# Altium schematic sheet [03] - ARDUINO SCHEMATIC.SchDoc("[03] - ARDUINO SCHEMATIC") (continued)

## Library data of the parts
C1 C12 C16 C18 C19 C21 C33 = C2012X5R1C106M125AC: CAP CER 10UF 16V X5R 0805; PCN Part Number: MLCC Part Number Change 30/Nov/2012; Manufacturer: TDK; Tolerance: ±20%; Product Training Modules: C Series General Applications SEAT, CCV, and TVCL Design Tools Guide to Replacing an Electrolytic Capacitor with an MLCC; Other Names: 445-4115-2 C2012X5R1C106M C2012X5R1C106M/1.25 C2012X5R1C106MT C2012X5R1C106MTJ00E; Online Catalog: C Series, General Purpose; Catalog Drawings: C SERIES_2012-0805_1.45; Capacitance: 10µF; Thickness (Max): 0.057" (1.45mm); Temperature Coefficient: X5R; Supplier Part Number 1: 445-4115-1-ND; Supplier 1: Digi-Key; Stock 1: 0; Standard Package: 2,000; Size / Dimension: 0.079" L x 0.049" W (2.00mm x 1.25mm); Series: C; RoHS Information: RoHS Certificate-MLCC; Ratings: -; Packaging: Tape & Reel (TR); Package / Case: 0805 (2012 Metric); Operating Temperature: -55°C ~ 85°C; Mounting Type: Surface Mount, MLCC; Manufacturer Part Number: C2012X5R1C106M125AC; Library Name: Arduino_Project_V1I1.SchLib; Lead Style: -; Lead Spacing: -; Height - Seated (Max): -; Features: -; Family: Ceramic Capacitors; ComponentLink3URL: https://product.tdk.com/info/en/documents/chara_sheet/C2012X5R1C106M125AC.pdf?src-supplier=Newark; ComponentLink3Description: https://product.tdk.com/info/en/documents/chara_sheet/C2012X5R1C106M125AC.pdf?src-supplier=Newark; ComponentLink2URL: http://www.farnell.com/datasheets/1913358.pdf?src-supplier=Element14; ComponentLink2Description: http://www.farnell.com/datasheets/1913358.pdf?src-supplier=Element14; ComponentLink1URL: https://media.digikey.com/pdf/Data%20Sheets/TDK%20PDFs/Multilayer%20Ceramic%20Chip%20Cap(up%20to%2050V).pdf?src-supplier=Digi-Key; ComponentLink1Description: https://media.digikey.com/pdf/Data%20Sheets/TDK%20PDFs/Multilayer%20Ceramic%20Chip%20Cap(up%20to%2050V).pdf?src-supplier=Digi-Key; Component Kind: Standard; Category: Capacitors; Applications: General Purpose
  C1: Voltage - Rated: 16V
C2-C4 C8 C11 C13-C15 C17 C20 C22 C23 C26-C32 = C2012X7R1H104K085AA: CAP CER 0.1UF 50V X7R 0805; PCN Part Number: MLCC Part Number Change 30/Nov/2012; Manufacturer: TDK; Tolerance: ±10%; Product Training Modules: C Series General Applications SEAT, CCV, and TVCL Design Tools Guide to Replacing an Electrolytic Capacitor with an MLCC; Other Names: 445-7534-1; Online Catalog: C Series, General Purpose; Kits: 445-9015-KIT-ND  - KIT MLCC 1000PF-47UF 0805 10-50V; Capacitance: 0.1µF; Voltage - Rated: 50V; Thickness (Max): 0.037" (0.95mm); Temperature Coefficient: X7R; Supplier Part Number 1: 445-7534-1-ND; Supplier 1: Digi-Key; Stock 1: 240552; Standard Package: 1; Size / Dimension: 0.079" L x 0.049" W (2.00mm x 1.25mm); Series: C; RoHS Information: RoHS Certificate-MLCC; Ratings: -; Pricing 1: 1=0.17, 10=0.119, 100=0.0561, 500=0.0394, 1000=0.0326 (USD); Packaging: Cut Tape (CT); Package / Case: 0805 (2012 Metric); Operating Temperature: -55°C ~ 125°C; Mounting Type: Surface Mount, MLCC; Manufacturer Part Number: C2012X7R1H104K085AA; Library Name: Arduino_Project_V1I1.SchLib; Lead Style: -; Lead Spacing: -; Height - Seated (Max): -; Features: -; Family: Ceramic Capacitors; ComponentLink5URL: http://www.farnell.com/datasheets/1571323.pdf?src-supplier=Element14; ComponentLink5Description: http://www.farnell.com/datasheets/1571323.pdf?src-supplier=Element14; ComponentLink4URL: http://www.farnell.com/datasheets/1796654.pdf?src-supplier=Newark; ComponentLink4Description: http://www.farnell.com/datasheets/1796654.pdf?src-supplier=Newark; ComponentLink3URL: http://www.tdk.co.jp/tefe02/e412_c.pdf?src-supplier=Future+Electronics; ComponentLink3Description: http://www.tdk.co.jp/tefe02/e412_c.pdf?src-supplier=Future+Electronics; ComponentLink2URL: https://product.tdk.com/info/en/catalog/datasheets/mlcc_commercial_general_en.pdf?src-supplier=Digi-Key; ComponentLink2Description: https://product.tdk.com/info/en/catalog/datasheets/mlcc_commercial_general_en.pdf?src-supplier=Digi-Key; ComponentLink1URL: http://uk.rs-online.com/webdocs/1532/0900766b81532cd0.pdf?src-supplier=RSComponents; ComponentLink1Description: http://uk.rs-online.com/webdocs/1532/0900766b81532cd0.pdf?src-supplier=RSComponents; Component Kind: Standard; Category: Capacitors; Applications: General Purpose
C5 C6 = EEE-1AA101WR: Cap Aluminum 100uF 10V 20% (5 X 5.4mm) SMD 50mA 1000 hr 85°C T/R; Manufacturer: Panasonic; Type: Can; Lifetime@Temperature: 2000 Hrs @ 85°C; Capacitance: 100 µF; Supplier Part Number 1: PCE3867CT-ND; Supplier 1: Digi-Key; Stock 1: 175082; Series: S; Ripple Current: 50 mA; Rated Voltage: 10 V; Pricing 1: 1=0.32, 10=0.221, 100=0.1328, 500=0.0996 (USD); Operating Temp Range: -40 to +85 °C; Operating Temp-Max: 85 °C; Manufacturer Part Number: EEE-1AA101WR; Library Name: Arduino_Project_V1I1.SchLib; ComponentLink5URL: https://industrial.panasonic.com/cdbs/www-data/pdf/RDE0000/DME0000COL90.pdf?src-supplier=Verical; ComponentLink5Description: https://industrial.panasonic.com/cdbs/www-data/pdf/RDE0000/DME0000COL90.pdf?src-supplier=Verical; ComponentLink4URL: https://industrial.panasonic.com/cdbs/www-data/pdf/RDE0000/DME0000COL90.pdf?src-supplier=Allied+Electronics; ComponentLink4Description: https://industrial.panasonic.com/cdbs/www-data/pdf/RDE0000/DME0000COL90.pdf?src-supplier=Allied+Electronics; ComponentLink3URL: http://industrial.panasonic.com/www-data/pdf/ABA0000/ABA0000CE2.pdf?src-supplier=Future+Electronics; ComponentLink3Description: http://industrial.panasonic.com/www-data/pdf/ABA0000/ABA0000CE2.pdf?src-supplier=Future+Electronics; ComponentLink2URL: https://industrial.panasonic.com/cdbs/www-data/pdf/RDE0000/DME0000COL90.pdf?src-supplier=Mouser; ComponentLink2Description: https://industrial.panasonic.com/cdbs/www-data/pdf/RDE0000/DME0000COL90.pdf?src-supplier=Mouser; ComponentLink1URL: https://industrial.panasonic.com/cdbs/www-data/pdf/RDE0000/DME0000COL90.pdf?src-supplier=Avnet; ComponentLink1Description: https://industrial.panasonic.com/cdbs/www-data/pdf/RDE0000/DME0000COL90.pdf?src-supplier=Avnet; Component Kind: Standard; Case Size/Dimension: Ø 5 x 5.4 mm
C7 = C2012X5R1E105K125AA: CAP CER 1UF 25V X5R 0805; PCN Part Number: MLCC Part Number Change 30/Nov/2012; Manufacturer: TDK; Tolerance: ±10%; Product Training Modules: High Capacitance Replacement C Series General Applications SEAT, CCV, and TVCL Design Tools Guide to Replacing an Electrolytic Capacitor with an MLCC; Other Names: 445-1419-6; Online Catalog: C Series, General Purpose; Catalog Drawings: C SERIES_2012-0805_1.45; Capacitance: 1µF; Voltage - Rated: 25V; Thickness (Max): 0.057" (1.45mm); Temperature Coefficient: X5R; Supplier Part Number 1: 445-1419-1-ND; Supplier 1: Digi-Key; Stock 1: 9238; Standard Package: 1; Size / Dimension: 0.079" L x 0.049" W (2.00mm x 1.25mm); Series: C; RoHS Information: RoHS Certificate-MLCC; Ratings: -; Pricing 1: 1=0.27, 10=0.182, 100=0.0923, 500=0.0631, 1000=0.0559 (USD); Packaging: Digi-Reel®; Package / Case: 0805 (2012 Metric); Operating Temperature: -55°C ~ 85°C; Mounting Type: Surface Mount, MLCC; Manufacturer Part Number: C2012X5R1E105K125AA; Library Name: Arduino_Project_V1I1.SchLib; Lead Style: -; Lead Spacing: -; Height - Seated (Max): -; Features: -; Family: Ceramic Capacitors; ComponentLink4URL: https://product.tdk.com/info/en/catalog/spec/mlccspec_commercial_general_midvoltage_en.pdf?src-supplier=Verical; ComponentLink4Description: https://product.tdk.com/info/en/catalog/spec/mlccspec_commercial_general_midvoltage_en.pdf?src-supplier=Verical; ComponentLink3URL: http://www.farnell.com/datasheets/1744655.pdf?src-supplier=Element14; ComponentLink3Description: http://www.farnell.com/datasheets/1744655.pdf?src-supplier=Element14; ComponentLink2URL: http://www.tdk.co.jp/tefe02/e412_c.pdf?src-supplier=Future+Electronics; ComponentLink2Description: http://www.tdk.co.jp/tefe02/e412_c.pdf?src-supplier=Future+Electronics; ComponentLink1URL: http://uk.rs-online.com/webdocs/1532/0900766b81532cd0.pdf?src-supplier=RSComponents; ComponentLink1Description: http://uk.rs-online.com/webdocs/1532/0900766b81532cd0.pdf?src-supplier=RSComponents; Component Kind: Standard; Category: Capacitors; Applications: General Purpose
C9 C10 C24 C25 = C0805C180J5GACTU: CAP CER 18PF 50V C0G/NP0 0805; Manufacturer: KEMET; Voltage: 50 Vdc; Tolerance: 5 %; Product Length: 2 mm; Product Height: 0.78 mm; Product Depth: 1.25 mm; Dielectric: C0G; Supplier Part Number 1: 399-1112-1-ND; Supplier 1: Digi-Key; Stock 1: 70636; Pricing 1: 1=0.14, 10=0.1, 100=0.0468, 500=0.0328, 1000=0.0272 (USD); Package/Case: 0805; Operating Temperature: -55 to 125 °C; Mounting: Surface Mount; Manufacturer Part Number: C0805C180J5GACTU; Library Name: Arduino_Project_V1I1.SchLib; Construction: Flat; ComponentLink3URL: https://www.verical.com/server-webapp/html/getDatasheet?partID=759702&src-supplier=Verical; ComponentLink3Description: https://www.verical.com/server-webapp/html/getDatasheet?partID=759702&src-supplier=Verical; ComponentLink2URL: https://www.verical.com/server-webapp/html/getDatasheet?partID=385668&src-supplier=Verical; ComponentLink2Description: https://www.verical.com/server-webapp/html/getDatasheet?partID=385668&src-supplier=Verical; ComponentLink1URL: http://www.farnell.com/datasheets/1769375.pdf?src-supplier=Element14; ComponentLink1Description: http://www.farnell.com/datasheets/1769375.pdf?src-supplier=Element14; Component Kind: Standard; Category: Capacitor Ceramic Multilayer; Capacitance Value: 18 pF
D1 D2 = BAT54HT1G: DIODE SCHOTTKY 30V 200MA SOD323; Manufacturer: ON Semiconductor / Fairchild; Type: Small Signal Schottky Diode; Rad Hard: No; Peak Reverse Recovery Time: 5 ns; Peak Reverse Current: 2 uA; Peak Non-Repetitive Surge Current: 0.6 A; Peak Forward Voltage: 0.8@0.1A V; Peak Average Forward Current: 0.2 A; Configuration: Single; Supplier Part Number 1: BAT54HT1GCT-ND; Supplier 1: Digi-Key; Stock 1: 0; Peak Reverse Repetitive Voltage: 30 V; Packaging: Tape & Reel; Package: 2SOD-323; Operating Temperature: -55 to 150 °C; Mounting: Surface Mount; Manufacturer Part Number: BAT54HT1G; Library Name: Arduino_Project_V1I1.SchLib; ComponentLink13URL: http://www.produktinfo.conrad.com/datenblaetter/1200000-1299999/001262925-da-01-en-DIODE_SCHOTTKY_30V_BAT54HT1G_SOD_323_FSC.pdf?src-supplier=Conrad; ComponentLink13Description: http://www.produktinfo.conrad.com/datenblaetter/1200000-1299999/001262925-da-01-en-DIODE_SCHOTTKY_30V_BAT54HT1G_SOD_323_FSC.pdf?src-supplier=Conrad; ComponentLink12URL: http://www.fairchildsemi.com/ds/BA/BAT54HT1G.pdf?src-supplier=Future+Electronics; ComponentLink12Description: http://www.fairchildsemi.com/ds/BA/BAT54HT1G.pdf?src-supplier=Future+Electronics; ComponentLink11URL: https://media.digikey.com/pdf/Data%20Sheets/Fairchild%20PDFs/BAT54HT1G_Rev_1.2.pdf?src-supplier=Mouser; ComponentLink11Description: https://media.digikey.com/pdf/Data%20Sheets/Fairchild%20PDFs/BAT54HT1G_Rev_1.2.pdf?src-supplier=Mouser; ComponentLink10URL: http://uk.rs-online.com/webdocs/11a6/0900766b811a63a9.pdf?src-supplier=RSComponents; ComponentLink10Description: http://uk.rs-online.com/webdocs/11a6/0900766b811a63a9.pdf?src-supplier=RSComponents; ComponentLink9URL: http://www.onsemi.com/pub/Collateral/BAT54HT1-D.PDF?src-supplier=Digi-Key; ComponentLink9Description: http://www.onsemi.com/pub/Collateral/BAT54HT1-D.PDF?src-supplier=Digi-Key; ComponentLink8URL: http://www.onsemi.com/pub/Collateral/BAT54HT1-D.PDF?src-supplier=Avnet; ComponentLink8Description: http://www.onsemi.com/pub/Collateral/BAT54HT1-D.PDF?src-supplier=Avnet; ComponentLink7URL: https://media.digikey.com/pdf/Data%20Sheets/Fairchild%20PDFs/BAT54HT1G_Rev_1.2.pdf?src-supplier=Avnet; ComponentLink7Description: https://media.digikey.com/pdf/Data%20Sheets/Fairchild%20PDFs/BAT54HT1G_Rev_1.2.pdf?src-supplier=Avnet; ComponentLink6URL: http://www.farnell.com/datasheets/1727620.pdf?src-supplier=Element14; ComponentLink6Description: http://www.farnell.com/datasheets/1727620.pdf?src-supplier=Element14; ComponentLink5URL: http://www.mouser.com/ds/2/149/BAT54HT1G-104602.pdf?src-supplier=Mouser; ComponentLink5Description: http://www.mouser.com/ds/2/149/BAT54HT1G-104602.pdf?src-supplier=Mouser; ComponentLink4URL: http://www.farnell.com/datasheets/2251626.pdf?src-supplier=Newark; ComponentLink4Description: http://www.farnell.com/datasheets/2251626.pdf?src-supplier=Newark; ComponentLink3URL: http://www.jameco.com/Jameco/Products/ProdDS/806549.pdf?src-supplier=Jameco; ComponentLink3Description: http://www.jameco.com/Jameco/Products/ProdDS/806549.pdf?src-supplier=Jameco; ComponentLink2URL: http://www.farnell.com/datasheets/2251626.pdf?src-supplier=Element14; ComponentLink2Description: http://www.farnell.com/datasheets/2251626.pdf?src-supplier=Element14; ComponentLink1URL: http://www.fairchildsemi.com/datasheets/BA/BAT54HT1G.pdf?src-supplier=Digi-Key; ComponentLink1Description: http://www.fairchildsemi.com/datasheets/BA/BAT54HT1G.pdf?src-supplier=Digi-Key; Component Kind: Standard; Category: Rectifier
FB1-FB3 = MPZ2012S601AT000: Ferrite Beads Multi-Layer Power 600Ohm 25% 100MHz 2A 100mOhm DCR 0805 T/R; PCN Part Number: MMZ,MPS Series Oct/2014; Manufacturer: TDK; Product Training Modules: EMC Components; Other Names: 445-2206-6; Online Catalog: MPZ2012 Series; Impedance @ Frequency: 600 Ohm @ 100MHz; Filter Type: Differential Mode - Single; DC Resistance (DCR): 100 mOhm Max; Catalog Drawings: (MMZ,MPZ)2012 Series Top    (MMZ,MPZ)2012 Series Footprint    (MMZ,MPZ)2012 Series Side; Supplier Part Number 1: 445-2206-1-ND; Supplier 1: Digi-Key; Stock 1: 126683; Standard Package: 1; Size / Dimension: 0.079" L x 0.049" W (2.00mm x 1.25mm); Series: MPZ; Pricing 1: 1=0.11, 10=0.091, 25=0.0704, 50=0.0584, 100=0.0503, 250=0.0403, 500=0.0362, 1000=0.0302 (USD); Packaging: Digi-Reel®; Package / Case: 0805 (2012 Metric); Mounting Type: Surface Mount; Manufacturer Part Number: MPZ2012S601AT000; Library Name: Arduino_Project_V1I1.SchLib; Height (Max): 0.041" (1.05mm); Family: Ferrite Beads and Chips; Current Rating: 2A; ComponentLink2URL: https://product.tdk.com/info/en/catalog/datasheets/beads_commercial_power_mpz2012_en.pdf?src-supplier=Digi-Key; ComponentLink2Description: https://product.tdk.com/info/en/catalog/datasheets/beads_commercial_power_mpz2012_en.pdf?src-supplier=Digi-Key; ComponentLink1URL: https://media.digikey.com/pdf/Data%20Sheets/TDK%20PDFs/MPZ_Series_Br.pdf?src-supplier=Digi-Key; ComponentLink1Description: https://media.digikey.com/pdf/Data%20Sheets/TDK%20PDFs/MPZ_Series_Br.pdf?src-supplier=Digi-Key; Component Kind: Standard; Category: Filters
FID1-FID4 = FID_1MMC: Fiducial 40mil Diameter, .20mil Mask; Library Name: Arduino_Project_V1I1.SchLib
J1 J3 = M20-7820842: 08-WAY SIL VERT SOCKET L/FREE; Manufacturer: Harwin; Rad Hard: No; Number of Contacts: 8; Gender: SKT; Type: Socket Strip; Termination Method: Solder; Supplier Part Number 1: 952-1823-ND; Supplier 1: Digi-Key; Stock 1: 2671; Pricing 1: 1=1.1, 10=0.972, 100=0.8382, 500=0.6858, 1000=0.6096, 5000=0.5334, 10000=0.5067 (USD); Pitch: 2.54 mm; Number of Rows: 1; Manufacturer Part Number: M20-7820842; Contact Plating: Gold; ComponentLink2URL: http://www.harwin.com/include/downloads/drawings/M20-782.PDF?src-supplier=Avnet; ComponentLink2Description: http://www.harwin.com/include/downloads/drawings/M20-782.PDF?src-supplier=Avnet; ComponentLink1URL: https://cdn.harwin.com/pdfs/Harwin_PC_Connectors_M20.pdf?src-supplier=Avnet+Europe; ComponentLink1Description: https://cdn.harwin.com/pdfs/Harwin_PC_Connectors_M20.pdf?src-supplier=Avnet+Europe; Component Kind: Standard; Category: Connector Headers and PCB Receptacles
  J1: Library Name: Arduino_Project_V1I1.SchLib
  J3: Library Name: 28pins_Project_V1I1.SchLib
J2 = M20-7820646: 06-WAY SIL VERT SOCKET L/FREE; Manufacturer: Harwin; Rad Hard: No; Number of Contacts: 6; Gender: SKT; Type: Socket Strip; Termination Method: Solder; Supplier Part Number 1: 952-1809-ND; Supplier 1: Digi-Key; Stock 1: 722; Pricing 1: 1=0.96, 10=0.842, 100=0.726, 500=0.594, 1000=0.528, 5000=0.462, 10000=0.4389 (USD); Pitch: 2.54 mm; Number of Rows: 1; Manufacturer Part Number: M20-7820646; Library Name: Arduino_Project_V1I1.SchLib; Contact Plating: Tin; Component Kind: Standard; Category: Connector Headers and PCB Receptacles
J4 = M20-7821046: 10-WAY SIL VERT SOCKET L/FREE; Manufacturer: Harwin; Number of Contacts: 10; Current Rating: 3A; Body Orientation: Straight; Type: Socket Strip; Termination Method: Solder; Supplier Part Number 1: 952-1847-ND; Supplier 1: Digi-Key; Stock 1: 49; Pricing 1: 1=1.28, 10=1.122, 100=0.968, 500=0.792, 1000=0.704, 5000=0.616, 10000=0.5852 (USD); Pitch: 2.54mm; Number of Rows: 1; Mounting Type: Through Hole; Manufacturer Part Number: M20-7821046; Library Name: Arduino_Project_V1I1.SchLib; Contact Material: Phosphor Bronze; ComponentLink5URL: http://uk.rs-online.com/webdocs/1422/0900766b81422659.pdf?src-supplier=RSComponents; ComponentLink5Description: http://uk.rs-online.com/webdocs/1422/0900766b81422659.pdf?src-supplier=RSComponents; ComponentLink4URL: https://cdn.harwin.com/pdfs/Harwin_PC_Connectors_M20.pdf?src-supplier=Avnet+Europe; ComponentLink4Description: https://cdn.harwin.com/pdfs/Harwin_PC_Connectors_M20.pdf?src-supplier=Avnet+Europe; ComponentLink3URL: http://www.harwin.com/include/downloads/drawings/M20-782.PDF?src-supplier=Avnet; ComponentLink3Description: http://www.harwin.com/include/downloads/drawings/M20-782.PDF?src-supplier=Avnet; ComponentLink2URL: https://cdn.harwin.com/pdfs/M20-782.pdf?src-supplier=Digi-Key; ComponentLink2Description: https://cdn.harwin.com/pdfs/M20-782.pdf?src-supplier=Digi-Key; ComponentLink1URL: https://www.verical.com/server-webapp/html/getDatasheet?partID=559226&src-supplier=Verical; ComponentLink1Description: https://www.verical.com/server-webapp/html/getDatasheet?partID=559226&src-supplier=Verical; Component Kind: Standard
J5 J6 = 67997-106HLF: CONN HEADER VERT 6POS 2.54MM; Manufacturer: Amphenol FCI; No. of Rows: 2; No. of Contacts: 6; Contact Termination: Through Hole Vertical; Supplier Part Number 1: 609-3393-ND; Supplier 1: Digi-Key; Stock 1: 972; Series: 67997; Pricing 1: 1=1.78, 10=1.614, 100=1.3837, 500=1.1531, 1000=0.9884, 5000=0.8763, 10000=0.8566 (USD); Pitch Spacing: 2.54mm; Manufacturer Part Number: 67997-106HLF; Library Name: Arduino_Project_V1I1.SchLib; Gender: Header; Contact Plating: Gold; Contact Material: Phosphor Bronze; ComponentLink4URL: https://cdn.amphenol-icc.com/media/wysiwyg/files/drawing/67996.pdf?src-supplier=Avnet+Europe; ComponentLink4Description: https://cdn.amphenol-icc.com/media/wysiwyg/files/drawing/67996.pdf?src-supplier=Avnet+Europe; ComponentLink3URL: https://cdn.amphenol-icc.com/media/wysiwyg/files/drawing/67996.pdf?src-supplier=Mouser; ComponentLink3Description: https://cdn.amphenol-icc.com/media/wysiwyg/files/drawing/67996.pdf?src-supplier=Mouser; ComponentLink2URL: https://cdn.amphenol-icc.com/media/wysiwyg/files/drawing/67996.pdf?src-supplier=Digi-Key; ComponentLink2Description: https://cdn.amphenol-icc.com/media/wysiwyg/files/drawing/67996.pdf?src-supplier=Digi-Key; ComponentLink1URL: https://cdn.amphenol-icc.com/media/wysiwyg/files/drawing/67996.pdf?src-supplier=Avnet; ComponentLink1Description: https://cdn.amphenol-icc.com/media/wysiwyg/files/drawing/67996.pdf?src-supplier=Avnet; Component Kind: Standard
J7 = 10118193-0001LF: CONN RCPT USB2.0 MICRO B SMD R/A; Manufacturer: Amphenol FCI; Number of Contacts: 5 Contact; Mounting Angle: Right; Insulation Resistance: 1000 MOhms; Gender: Female; Current Rating: 1.8 A; Connector Type: Micro USB Type B receptacle; Brand: FCI; Termination Style: SMD/SMT; Supplier Part Number 1: 609-4616-1-ND; Supplier 1: Digi-Key; Stock 1: 58058; Standard: USB 2.0; RoHS: Details; Product Category: USB Connectors; Product: Micro USB Type B Connectors; Pricing 1: 1=0.43, 10=0.405, 25=0.3648, 50=0.3242, 100=0.3107, 250=0.2837, 500=0.2702 (USD); Packaging: Reel; Operating Temperature Range: - 55 C to + 85 C; Manufacturer Part Number: 10118193-0001LF; Library Name: Arduino_Project_V1I1.SchLib; Flammability Rating: UL 94 V-0; Factory Pack Quantity: 1200; Contact Plating: Gold; Contact Material: Copper Alloy; ComponentLink6URL: https://cdn.amphenol-icc.com/media/wysiwyg/files/documentation/datasheet/inputoutput/io_usb_micro.pdf?src-supplier=Mouser; ComponentLink6Description: https://cdn.amphenol-icc.com/media/wysiwyg/files/documentation/datasheet/inputoutput/io_usb_micro.pdf?src-supplier=Mouser; ComponentLink5URL: https://cdn.amphenol-icc.com/media/wysiwyg/files/documentation/datasheet/inputoutput/io_usb_micro.pdf?src-supplier=Allied+Electronics; ComponentLink5Description: https://cdn.amphenol-icc.com/media/wysiwyg/files/documentation/datasheet/inputoutput/io_usb_micro.pdf?src-supplier=Allied+Electronics; ComponentLink4URL: https://cdn.amphenol-icc.com/media/wysiwyg/files/documentation/datasheet/inputoutput/io_usb_micro.pdf?src-supplier=Verical; ComponentLink4Description: https://cdn.amphenol-icc.com/media/wysiwyg/files/documentation/datasheet/inputoutput/io_usb_micro.pdf?src-supplier=Verical; ComponentLink3URL: http://www.amphenol-icc.com/media/wysiwyg/files/drawing/10118193.pdf?src-supplier=Digi-Key; ComponentLink3Description: http://www.amphenol-icc.com/media/wysiwyg/files/drawing/10118193.pdf?src-supplier=Digi-Key; ComponentLink2URL: https://cdn.amphenol-icc.com/media/wysiwyg/files/documentation/datasheet/inputoutput/io_usb_micro.pdf?src-supplier=Arrow; ComponentLink2Description: https://cdn.amphenol-icc.com/media/wysiwyg/files/documentation/datasheet/inputoutput/io_usb_micro.pdf?src-supplier=Arrow; ComponentLink1URL: https://cdn.amphenol-icc.com/media/wysiwyg/files/documentation/datasheet/inputoutput/io_usb_micro.pdf?src-supplier=Digi-Key; ComponentLink1Description: https://cdn.amphenol-icc.com/media/wysiwyg/files/documentation/datasheet/inputoutput/io_usb_micro.pdf?src-supplier=Digi-Key; Component Kind: Standard; Color: Black
J8 J9 = PAD_WITH_HOLE_4MMx1.5MM: Library Name: Arduino_Project_V1I1.SchLib
JP1 JP4 = 68000-103HLF: CONN HEADER VERT 3POS 2.54MM; Manufacturer: Amphenol FCI; Voltage Rating: 1.5 kV; Number of Positions / Contacts: 3; Mounting Angle: Vertical; Mating Post Length: 5.84 mm; Insulation Resistance: 5000 MOhms; Current Rating: 3 A; Brand: FCI; Type: Unshrouded; Termination Style: Through Hole; Termination Post Length: 2.41 mm; Supplier Part Number 1: 609-3461-ND; Supplier 1: Digi-Key; Stock 1: 29870; Series: 68000; RoHS: Details; Product Type: Headers; Product Category: Headers & Wire Housings; Pricing 1: 1=0.29, 10=0.279, 100=0.195, 500=0.1672, 1000=0.1393, 5000=0.1194, 10000=0.1114 (USD); Pitch: 2.54 mm; Packaging: Bulk; Operating Temperature Range: - 65 C to + 125 C; Number of Rows: 1; Number of Positions: 3; Mounting Style: Through Hole; Manufacturer Part Number: 68000-103HLF; Library Name: Arduino_Project_V1I1.SchLib; Housing Material: Thermoplastic; Flammability Rating: UL 94 V-0; Factory Pack Quantity: 100; Contact Plating: Gold/GTX; Contact Material: Phosphor Bronze; Contact Gender: Pin (Male); ComponentLink2URL: https://cdn.amphenol-icc.com/media/wysiwyg/files/drawing/68000.pdf?src-supplier=Avnet; ComponentLink2Description: https://cdn.amphenol-icc.com/media/wysiwyg/files/drawing/68000.pdf?src-supplier=Avnet; ComponentLink1URL: https://cdn.amphenol-icc.com/media/wysiwyg/files/drawing/68000.pdf?src-supplier=Mouser; ComponentLink1Description: https://cdn.amphenol-icc.com/media/wysiwyg/files/drawing/68000.pdf?src-supplier=Mouser; Component Kind: Standard
JP2 = 68602-204HLF: CONN HEADER VERT 4POS 2.54MM; Manufacturer: Amphenol FCI; Rad Hard: No; Number of Contacts: 4; Gender: HDR; Type: Unshrouded Header; Termination Method: Solder; Supplier Part Number 1: 609-3369-ND; Supplier 1: Digi-Key; Stock 1: 223; Pricing 1: 1=0.34, 10=0.319, 100=0.2232, 500=0.1913, 1000=0.1594, 5000=0.1367, 10000=0.1275 (USD); Pitch: 2.54 mm; Packaging: Bulk; Number of Rows: 2; Manufacturer Part Number: 68602-204HLF; Library Name: Arduino_Project_V1I1.SchLib; Contact Plating: Gold Over Nickel|GXT Over Nickel; ComponentLink1URL: https://cdn.amphenol-icc.com/media/wysiwyg/files/drawing/67996.pdf?src-supplier=Digi-Key; ComponentLink1Description: https://cdn.amphenol-icc.com/media/wysiwyg/files/drawing/67996.pdf?src-supplier=Digi-Key; Component Kind: Standard; Category: Connector Headers and PCB Receptacles
JP3 = 68001-204HLF: CONN HEADER VERT 4POS 2.54MM; Manufacturer: Amphenol FCI; Rad Hard: No; Number of Contacts: 4; Type: Unshrouded Header; Termination Method: Solder; Supplier Part Number 1: 609-3402-ND; Supplier 1: Digi-Key; Stock 1: 4815; Pricing 1: 1=0.38, 10=0.357, 100=0.2501, 500=0.2144, 1000=0.1787, 5000=0.1532, 10000=0.1429 (USD); Pitch: 2.54 mm; Packaging: Bulk; Number of Rows: 1; Manufacturer Part Number: 68001-204HLF; Library Name: Arduino_Project_V1I1.SchLib; Contact Plating: Gold Over Nickel|GXT Over Nickel; ComponentLink2URL: https://cdn.amphenol-icc.com/media/wysiwyg/files/drawing/68000.pdf?src-supplier=Mouser; ComponentLink2Description: https://cdn.amphenol-icc.com/media/wysiwyg/files/drawing/68000.pdf?src-supplier=Mouser; ComponentLink1URL: https://cdn.amphenol-icc.com/media/wysiwyg/files/drawing/68000.pdf?src-supplier=Digi-Key; ComponentLink1Description: https://cdn.amphenol-icc.com/media/wysiwyg/files/drawing/68000.pdf?src-supplier=Digi-Key; Component Kind: Standard; Category: Connector Headers and PCB Receptacles
L1 = L1210R2R2MDWIT: FIXED IND 2.2UH 2A 80 MOHM SMD; Manufacturer: KEMET; Type: Chip; Tolerance: 20 %; Rad Hard: No; Product Height: 2.7 mm; Maximum DC Resistance: 80(Typ) mOhm; Maximum DC Current: 1.13 A; Supplier Part Number 1: 399-9598-1-ND; Supplier 1: Digi-Key; Stock 1: 20876; Product Depth: 2.7 mm; Pricing 1: 1=0.55, 10=0.479, 100=0.3421, 500=0.2874 (USD); Package/Case: 1210; Manufacturer Part Number: L1210R2R2MDWIT; Library Name: Arduino_Project_V1I1.SchLib; Inductance: 2.2 uH; ComponentLink5URL: http://www.kemet.com/Lists/ProductCatalog/Attachments/57/F3115.pdf?src-supplier=Avnet; ComponentLink5Description: http://www.kemet.com/Lists/ProductCatalog/Attachments/57/F3115.pdf?src-supplier=Avnet; ComponentLink4URL: https://api.kemet.com/component-edge/download/datasheet/L1210R2R2MDWIT.pdf?src-supplier=Digi-Key; ComponentLink4Description: https://api.kemet.com/component-edge/download/datasheet/L1210R2R2MDWIT.pdf?src-supplier=Digi-Key; ComponentLink3URL: http://www.farnell.com/datasheets/27262.pdf?src-supplier=Element14; ComponentLink3Description: http://www.farnell.com/datasheets/27262.pdf?src-supplier=Element14; ComponentLink2URL: https://content.kemet.com/datasheets/F3115.pdf?src-supplier=Avnet+Europe; ComponentLink2Description: https://content.kemet.com/datasheets/F3115.pdf?src-supplier=Avnet+Europe; ComponentLink1URL: https://content.kemet.com/datasheets/F3115.pdf?src-supplier=Mouser; ComponentLink1Description: https://content.kemet.com/datasheets/F3115.pdf?src-supplier=Mouser; Component Kind: Standard
LED1 LED2 LED4 = LOL29K-H2K1-24-Z: LED ORANGE DIFFUSED 0603 SMD; Manufacturer: Osram Opto; Wavelength - Peak: 610nm; Wavelength - Dominant: 606nm; Voltage - Forward (Vf) (Typ): 1.8V; Viewing Angle: 160°; Millicandela Rating: 6.3mcd; Lens Style: Rectangle with Flat Top; Lens Size: 1.30mm x 0.80mm; Lens Color: White; Current - Test: 2mA; Configuration: Standard; Categories: Optoelectronics; Supplier Part Number 1: 475-2740-1-ND; Supplier Device Package: 0603; Supplier 1: Digi-Key; Stock 1: 6729; Size / Dimension: 1.70mm L x 0.80mm W; Series: SmartLED; Pricing 1: 1=0.43, 10=0.308, 100=0.2009, 500=0.1444, 1000=0.113, 2500=0.1036 (USD); Part Status: Active; Packaging: Digi-Reel®; Package / Case: 0603 (1608 Metric); Mounting Type: Surface Mount; Manufacturer Part Number: LOL29K-H2K1-24-Z; Library Name: Arduino_Project_V1I1.SchLib; Lens Transparency: Diffused; Height (Max): 0.65mm; Features: -; ComponentLink2URL: https://www.verical.com/server-webapp/html/getDatasheet?partID=693885&src-supplier=Verical; ComponentLink2Description: https://www.verical.com/server-webapp/html/getDatasheet?partID=693885&src-supplier=Verical; ComponentLink1URL: https://dammedia.osram.info/media/resource/hires/osram-dam-2493882/LO%20L29K.pdf?src-supplier=Digi-Key; ComponentLink1Description: https://dammedia.osram.info/media/resource/hires/osram-dam-2493882/LO%20L29K.pdf?src-supplier=Digi-Key; Component Kind: Standard; Color: Orange
LED3 = LGL29K-G2J1-24-Z: LED Uni-Color Green 572nm 2-Pin Chip 0603(1608Metric) T/R; Manufacturer: Osram Opto; Wavelength - Dominant: 570nm; Voltage - Forward (Vf) (Typ): 1.7V; Viewing Angle: 160°; Millicandela Rating: 3.92mcd; Lens Transparency: Diffused; Lens Style: Rectangle with Flat Top; Lens Size: 1.30mm x 0.80mm; Lens Color: White; Current - Test: 2mA; Configuration: Standard; Categories: Optoelectronics; Wavelength - Peak: 572nm; Supplier Part Number 1: 475-2709-6-ND; Supplier Device Package: 0603; Supplier 1: Digi-Key; Stock 1: 95091; Size / Dimension: 1.70mm L x 0.80mm W; Series: SmartLED; Pricing 1: 1=0.44, 10=0.319, 100=0.2082, 500=0.1496, 1000=0.1171, 2500=0.1074 (USD); Part Status: Active; Packaging: Digi-Reel®; Package / Case: 0603 (1608 Metric); Mounting Type: Surface Mount; Manufacturer Part Number: LGL29K-G2J1-24-Z; Library Name: Arduino_Project_V1I1.SchLib; Height (Max): 0.65mm; Features: -; ComponentLink3URL: https://www.verical.com/server-webapp/html/getDatasheet?partID=571127&src-supplier=Verical; ComponentLink3Description: https://www.verical.com/server-webapp/html/getDatasheet?partID=571127&src-supplier=Verical; ComponentLink2URL: https://dammedia.osram.info/media/resource/hires/osram-dam-2493945/LG%20L29K.pdf?src-supplier=Digi-Key; ComponentLink2Description: https://dammedia.osram.info/media/resource/hires/osram-dam-2493945/LG%20L29K.pdf?src-supplier=Digi-Key; ComponentLink1URL: http://www.mouser.com/ds/2/311/LG%20L29K%20-%20SMARTLED%200603-335234.pdf?src-supplier=Mouser; ComponentLink1Description: http://www.mouser.com/ds/2/311/LG%20L29K%20-%20SMARTLED%200603-335234.pdf?src-supplier=Mouser; Component Kind: Standard; Color: Green
LINK1-LINK3 = QPC02SXGN-RC: CONN JUMPER SHORTING .100" GOLD; Manufacturer: Sullins; Pitch: 0.100" (2.54mm); Other Names: S9337; Number of Positions or Pins (Grid): 2 (1 x 2); Gender: Female Sockets; Dynamic Catalog: Shunts and Jumpers - Sullins Connector Solutions; Type: Open Top; Supplier Part Number 1: S9337-ND; Supplier 1: Digi-Key; Stock 1: 62755; Standard Package: 2,000; Pricing 1: 1=0.1, 10=0.059, 25=0.044, 50=0.0378, 100=0.0365, 250=0.0315, 500=0.0302, 1000=0.0265, 2500=0.0239 (USD); Packaging: Bulk; Manufacturer Part Number: QPC02SXGN-RC; Library Name: Arduino_Project_V1I1.SchLib; Height: 0.235" (5.97mm); Family: Shunts, Jumpers; Contact Finish Thickness: Flash; Contact Finish: Gold; ComponentLink1URL: https://s3.amazonaws.com/catalogspreads-pdf/PAGE128-129%20.100%20JUMPER.pdf?src-supplier=Digi-Key; ComponentLink1Description: https://s3.amazonaws.com/catalogspreads-pdf/PAGE128-129%20.100%20JUMPER.pdf?src-supplier=Digi-Key; Category: Connectors, Interconnects
MH1-MH3 = MH_7.4MMC_3.2MMD: Mounting Hole 7.4mm Diameter, 3.2mm Drill; Library Name: Arduino_Project_V1I1.SchLib
MH4 = MH_7.4MMNC_3.2MMD: Mounting Hole 7.4mm Diameter(Non Copper), 3.2mm Drill NPTH; Library Name: Arduino_Project_V1I1.SchLib
R1 = RC0805FR-07100KL: RES SMD 100K OHM 1% 1/8W 0805; Manufacturer: Yageo; Voltage Rating: 150 V; Temperature Coefficient: 100 PPM / C; Resistance: 100 kOhms; Power Rating: 125 mW (1/8 W); Tolerance: 1 %; Termination Style: SMD/SMT; Supplier Part Number 1: 311-100KCRCT-ND; Supplier 1: Digi-Key; Stock 1: 6340795; Series: RC; Product: Thick Film Resistors General Purpose; Pricing 1: 1=0.1, 10=0.04, 100=0.0163, 1000=0.0073, 2500=0.0064 (USD); Packaging: Reel; Operating Temperature Range: - 55 C to + 155 C; Manufacturer Part Number: RC0805FR-07100KL; Library Name: Arduino_Project_V1I1.SchLib; ComponentLink8URL: http://www.farnell.com/datasheets/444164.pdf?src-supplier=Element14; ComponentLink8Description: http://www.farnell.com/datasheets/444164.pdf?src-supplier=Element14; ComponentLink7URL: http://www.farnell.com/datasheets/1686128.pdf?src-supplier=Element14; ComponentLink7Description: http://www.farnell.com/datasheets/1686128.pdf?src-supplier=Element14; ComponentLink6URL: http://www.farnell.com/datasheets/2167052.pdf?src-supplier=Element14; ComponentLink6Description: http://www.farnell.com/datasheets/2167052.pdf?src-supplier=Element14; ComponentLink5URL: http://www.farnell.com/datasheets/38627.pdf?src-supplier=Element14; ComponentLink5Description: http://www.farnell.com/datasheets/38627.pdf?src-supplier=Element14; ComponentLink4URL: http://www.yageo.ru/pdf/RC0805.pdf?src-supplier=Future+Electronics; ComponentLink4Description: http://www.yageo.ru/pdf/RC0805.pdf?src-supplier=Future+Electronics; ComponentLink3URL: http://documents.rs-components.com/techlib://itc_doclist_GB.htm?stockno=6185113&src-supplier=RSComponents; ComponentLink3Description: http://documents.rs-components.com/techlib://itc_doclist_GB.htm?stockno=6185113&src-supplier=RSComponents; ComponentLink2URL: http://www.yageo.com/documents/recent/PYu-RC_Group_51_RoHS_L_9.pdf?src-supplier=Mouser; ComponentLink2Description: http://www.yageo.com/documents/recent/PYu-RC_Group_51_RoHS_L_9.pdf?src-supplier=Mouser; ComponentLink1URL: http://www.yageo.com/documents/recent/PYu-RC_Group_51_RoHS_L_10.pdf?src-supplier=Digi-Key; ComponentLink1Description: http://www.yageo.com/documents/recent/PYu-RC_Group_51_RoHS_L_10.pdf?src-supplier=Digi-Key; Component Kind: Standard; Case Code - mm: 2012; Case Code - in: 0805
R2 R3 R18 R20 R22 = RC0805FR-07560RL: RES SMD 560 OHM 1% 1/8W 0805; Manufacturer: Yageo; Voltage Rating: 150 V; Unit Weight: 0.000194 oz; Temperature Coefficient: 100 PPM / C; Resistance: 560 Ohms; Power Rating: 125 mW (1/8 W); Width: 1.25 mm; Type: General Purpose Chip Resistor Surface Mount; Tolerance: 1 %; Termination Style: SMD/SMT; Supplier Part Number 1: 311-560CRDKR-ND; Supplier 1: Digi-Key; Stock 1: 448756; Series: RC; RoHS: True; Product Category: Thick Film Resistors; Product: Thick Film Resistors General Purpose; Pricing 1: 1=0.1, 10=0.04, 100=0.0163, 1000=0.0073, 2500=0.0064 (USD); Packaging: Reel; Package / Case: 0805 (2012 metric); Operating Temperature Range: - 55 C to + 155 C; Manufacturer Part Number: RC0805FR-07560RL; Library Name: Arduino_Project_V1I1.SchLib; Length: 2 mm; Height: 0.5 mm; ComponentLink3URL: http://www.farnell.com/datasheets/2167052.pdf?src-supplier=Element14; ComponentLink3Description: http://www.farnell.com/datasheets/2167052.pdf?src-supplier=Element14; ComponentLink2URL: http://www.yageo.com/documents/recent/PYu-RC_Group_51_RoHS_L_9.pdf?src-supplier=Mouser; ComponentLink2Description: http://www.yageo.com/documents/recent/PYu-RC_Group_51_RoHS_L_9.pdf?src-supplier=Mouser; ComponentLink1URL: http://www.yageo.com/documents/recent/PYu-RC_Group_51_RoHS_L_10.pdf?src-supplier=Digi-Key; ComponentLink1Description: http://www.yageo.com/documents/recent/PYu-RC_Group_51_RoHS_L_10.pdf?src-supplier=Digi-Key; Component Kind: Standard; Case Code - mm: 2012; Case Code - in: 0805; Brand: Yageo
R4 R9 R14 R15 = RC0805JR-070RL: RES SMD 0 OHM JUMPER 1/8W 0805; Manufacturer: Yageo; Voltage Rating: 150 V; Tolerance: 5 %; Temperature Coefficient: 200 PPM / C; Resistance: 0 Ohms; Power Rating: 125 mW (1/8 W); Termination Style: SMD/SMT; Supplier Part Number 1: 311-0.0ARCT-ND; Supplier 1: Digi-Key; Stock 1: 12398303; Series: RC; Product: Thick Film Resistors Zero-Ohm; Pricing 1: 1=0.1, 10=0.038, 25=0.0276, 50=0.021, 100=0.0154, 250=0.0118, 500=0.0094, 1000=0.0069 (USD); Packaging: Reel; Operating Temperature Range: - 55 C to + 155 C; Manufacturer Part Number: RC0805JR-070RL; Library Name: Arduino_Project_V1I1.SchLib; ComponentLink3URL: https://www.verical.com/server-webapp/html/getDatasheet?partID=330685&src-supplier=Verical; ComponentLink3Description: https://www.verical.com/server-webapp/html/getDatasheet?partID=330685&src-supplier=Verical; ComponentLink2URL: http://documents.rs-components.com/techlib://itc_doclist_GB.htm?stockno=6185129&src-supplier=RSComponents; ComponentLink2Description: http://documents.rs-components.com/techlib://itc_doclist_GB.htm?stockno=6185129&src-supplier=RSComponents; ComponentLink1URL: http://www.farnell.com/datasheets/2167052.pdf?src-supplier=Element14; ComponentLink1Description: http://www.farnell.com/datasheets/2167052.pdf?src-supplier=Element14; Component Kind: Standard; Case Code - mm: 2012; Case Code - in: 0805
R5 R17 R21 R24 R26 R27 = RC0805FR-0710KL: RES SMD 10K OHM 1% 1/8W 0805; Manufacturer: Yageo; Voltage Rating: 150 V; Temperature Coefficient: 100 PPM / C; Resistance: 10 kOhms; Power Rating: 125 mW (1/8 W); Tolerance: 1 %; Termination Style: SMD/SMT; Supplier Part Number 1: 311-10.0KCRDKR-ND; Supplier 1: Digi-Key; Stock 1: 34392046; Series: RC; Product: Thick Film Resistors General Purpose; Pricing 1: 1=0.1, 10=0.04, 100=0.0163, 1000=0.0073, 2500=0.0064 (USD); Packaging: Reel; Operating Temperature Range: - 55 C to + 155 C; Manufacturer Part Number: RC0805FR-0710KL; Library Name: Arduino_Project_V1I1.SchLib; ComponentLink4URL: http://www.yageo.ru/pdf/RC0805.pdf?src-supplier=Future+Electronics; ComponentLink4Description: http://www.yageo.ru/pdf/RC0805.pdf?src-supplier=Future+Electronics; ComponentLink3URL: http://www.yageo.com/documents/recent/PYu-RC_Group_51_RoHS_L_10.pdf?src-supplier=Digi-Key; ComponentLink3Description: http://www.yageo.com/documents/recent/PYu-RC_Group_51_RoHS_L_10.pdf?src-supplier=Digi-Key; ComponentLink2URL: http://www.farnell.com/datasheets/2167052.pdf?src-supplier=Element14; ComponentLink2Description: http://www.farnell.com/datasheets/2167052.pdf?src-supplier=Element14; ComponentLink1URL: http://www.yageo.com/documents/recent/PYu-RC_Group_51_RoHS_L_9.pdf?src-supplier=Mouser; ComponentLink1Description: http://www.yageo.com/documents/recent/PYu-RC_Group_51_RoHS_L_9.pdf?src-supplier=Mouser; Component Kind: Standard; Case Code - mm: 2012; Case Code - in: 0805
R6-R8 R16 R23 R25 R28 = RC0805FR-0722RL: RES SMD 22 OHM 1% 1/8W 0805; Manufacturer: Yageo; Voltage Rating: 150 V; Temperature Coefficient: 100 PPM / C; Resistance: 22 Ohms; Power Rating: 125 mW (1/8 W); Tolerance: 1 %; Termination Style: SMD/SMT; Supplier Part Number 1: 311-22.0CRCT-ND; Supplier 1: Digi-Key; Stock 1: 318742; Series: RC; Product: Thick Film Resistors General Purpose; Pricing 1: 1=0.1, 10=0.04, 100=0.0163, 1000=0.0073, 2500=0.0064 (USD); Packaging: Reel; Operating Temperature Range: - 55 C to + 155 C; Manufacturer Part Number: RC0805FR-0722RL; Library Name: Arduino_Project_V1I1.SchLib; ComponentLink3URL: http://www.yageo.com/documents/recent/PYu-RC_Group_51_RoHS_L_9.pdf?src-supplier=Mouser; ComponentLink3Description: http://www.yageo.com/documents/recent/PYu-RC_Group_51_RoHS_L_9.pdf?src-supplier=Mouser; ComponentLink2URL: http://www.farnell.com/datasheets/444164.pdf?src-supplier=Element14; ComponentLink2Description: http://www.farnell.com/datasheets/444164.pdf?src-supplier=Element14; ComponentLink1URL: http://www.yageo.com/documents/recent/PYu-RC_Group_51_RoHS_L_10.pdf?src-supplier=Digi-Key; ComponentLink1Description: http://www.yageo.com/documents/recent/PYu-RC_Group_51_RoHS_L_10.pdf?src-supplier=Digi-Key; Component Kind: Standard; Case Code - mm: 2012; Case Code - in: 0805
R10 R19 = RC0805FR-071ML: RES SMD 1M OHM 1% 1/8W 0805; Manufacturer: Yageo; Voltage Rating: 150 V; Temperature Coefficient: 100 PPM / C; Resistance: 1 MOhms; Power Rating: 125 mW (1/8 W); Tolerance: 1 %; Termination Style: SMD/SMT; Supplier Part Number 1: 311-1.00MCRCT-ND; Supplier 1: Digi-Key; Stock 1: 4917185; Series: RC; Product: Thick Film Resistors General Purpose; Pricing 1: 1=0.1, 10=0.04, 100=0.0163, 1000=0.0073, 2500=0.0064 (USD); Packaging: Reel; Operating Temperature Range: - 55 C to + 155 C; Manufacturer Part Number: RC0805FR-071ML; Library Name: Arduino_Project_V1I1.SchLib; ComponentLink3URL: http://www.yageo.com/documents/recent/PYu-RC_Group_51_RoHS_L_9.pdf?src-supplier=Mouser; ComponentLink3Description: http://www.yageo.com/documents/recent/PYu-RC_Group_51_RoHS_L_9.pdf?src-supplier=Mouser; ComponentLink2URL: http://www.yageo.ru/pdf/RC0805.pdf?src-supplier=Future+Electronics; ComponentLink2Description: http://www.yageo.ru/pdf/RC0805.pdf?src-supplier=Future+Electronics; ComponentLink1URL: http://www.yageo.com/documents/recent/PYu-RC_Group_51_RoHS_L_10.pdf?src-supplier=Digi-Key; ComponentLink1Description: http://www.yageo.com/documents/recent/PYu-RC_Group_51_RoHS_L_10.pdf?src-supplier=Digi-Key; Component Kind: Standard; Case Code - mm: 2012; Case Code - in: 0805
R11-R13 = RC0805FR-071KL: RES SMD 1K OHM 1% 1/8W 0805; Manufacturer: Yageo Phycomp; Supplier Part Number 1: 311-1.00KCRCT-ND; Supplier 1: Digi-Key; Stock 1: 24194326; Pricing 1: 1=0.1, 10=0.04, 100=0.0163, 1000=0.0073, 2500=0.0064 (USD); Manufacturer Part Number: RC0805FR-071KL; Library Name: Arduino_Project_V1I1.SchLib; Component Kind: Standard
SCKT_FOR_U3 = 4828-3004-CP: CONN IC DIP SOCKET 28POS TIN; Part # Aliases: 05111116010 80000917973; Manufacturer: 3M; Pitch: 2.54 mm; Number of Positions / Contacts: 28; Insulation Resistance: 5000 MOhms; Brand: 3M Electronic Solutions Division; Termination Style: Solder Tail; Supplier Part Number 1: 3M5480-ND; Supplier 1: Digi-Key; Stock 1: 0; RoHS: Details; Product Category: .100" Wire Mount Connectors; Product: DIP / SIP Sockets; Pricing 1: 1=0.79, 10=0.693, 25=0.6524, 50=0.6254, 100=0.5982, 250=0.5438, 500=0.4894, 1000=0.435, 2500=0.3943 (USD); Operating Temperature Range: - 25 C to + 85 C; Number of Rows: 2; Number of Positions: 28; Mounting Style: Through Hole; Manufacturer Part Number: 4828-3004-CP; Library Name: Arduino_Project_V1I1.SchLib; Factory Pack Quantity: 5600; Contact Plating: Tin; ComponentLink1URL: http://multimedia.3m.com/mws/media/218496O/3mtm-dip-socket-4800-series-ts1099.pdf?src-supplier=Digi-Key; ComponentLink1Description: http://multimedia.3m.com/mws/media/218496O/3mtm-dip-socket-4800-series-ts1099.pdf?src-supplier=Digi-Key; Component Kind: Standard
SW1 SW2 = 1825910-6: ALCOSWITCH - TE CONNECTIVITY - 1825910-6 - TACT SWITCH, SPST, 0.05A, 24VDC, SOLDER; Manufacturer: TE Connectivity Alcoswitch; Thread Size: N/R; Switch Configuration: OFF (ON); Minimum Insulation Resistance: 100 MOhm; Mechanical Life: 100000 Cycles; Maximum DC Voltage Rating: 24 V; Function: Momentary Contact; Dielectric Strength: 500 VAC; Contact Rating @ Voltage: 0.05A@24VDC; Bushing Type: Unthreaded; Body Orientation: Straight; Actuator Style: Round Button; Actuator Material: Polybutylene Terephthalate; Actuator Length: 1.4 mm; Actuator Color: Black; Terminal Type: PC Pins; Supplier Part Number 1: 450-1650-ND; Supplier 1: Digi-Key; Stock 1: 31172; Seal Location: N/A; Seal Description: N/A; RoHS: Yes; Product Weight: N/A; Pricing 1: 1=0.1, 10=0.101, 25=0.0976, 50=0.094, 100=0.0888, 250=0.0835, 500=0.0801, 1000=0.0609, 5000=0.0505 (USD); Pole Throw Configuration: SPST; Part No: 1825910-6; Packaging: N/A; Operating Temperature: -35 to 85 C; Mounting: Through Hole; Maximum Contact Resistance: 100 mOhm; Maximum AC Voltage Rating: N/A; Manufacturer Part Number: 1825910-6; Library Name: Arduino_Project_V1I1.SchLib; Illumination: N/R; Housing Material: N/A; Family: 1825910; Electrical Life: 100000 Cycles; Dimension: 5.99 x 5 mm; Contact Plating: Silver; Contact Material: Copper Alloy; ComponentLink2URL: http://www.te.com/commerce/DocumentDelivery/DDEController?Action=srchrtrv&DocNm=1825910&DocType=Customer+Drawing&DocLang=English&src-supplier=Verical; ComponentLink2Description: http://www.te.com/commerce/DocumentDelivery/DDEController?Action=srchrtrv&DocNm=1825910&DocType=Customer+Drawing&DocLang=English&src-supplier=Verical; ComponentLink1URL: http://www.onlinecomponents.com/productFiles/mf-AMP/1825910-6rqpn1825910-6.pdf?src-supplier=Onlinecomponents.com; ComponentLink1Description: http://www.onlinecomponents.com/productFiles/mf-AMP/1825910-6rqpn1825910-6.pdf?src-supplier=Onlinecomponents.com; Component Kind: Standard; Base Material: N/A
U1 = ATMEGA16U2-AU: MCU 8-bit ATmega AVR RISC 16KB Flash 3.3V/5V 32-Pin TQFP; Manufacturer: Microchip; Voltage - Supply (Vcc/Vdd): 2.7V ~ 5.5V; Speed: 16MHz; RAM Size: 512 x 8; Program Memory Size: 16KB (8K x 16); Peripherals: Brown-out Detect/Reset, POR, PWM, WDT; Oscillator Type: Internal; Number of I/O: 22; Connectivity: SPI, UART/USART, USB; Categories: Integrated Circuits (ICs); Supplier Part Number 1: ATMEGA16U2-AU-ND; Supplier Device Package: 32-TQFP (7x7); Supplier 1: Digi-Key; Stock 1: 870; Series: AVR® ATmega; Program Memory Type: FLASH; Pricing 1: 1=2.45, 25=2.25, 100=2.04 (USD); Part Status: Active; Packaging: Tray; Package / Case: 32-TQFP; Operating Temperature: -40°C ~ 85°C (TA); Manufacturer Part Number: ATMEGA16U2-AU; Library Name: Arduino_Project_V1I1.SchLib; EEPROM Size: 512 x 8; Data Converters: -; Core Size: 8-Bit; Core Processor: AVR; Component Kind: Standard; Base Part Number: ATMEGA16U2
U2 = TL1963A-33DCQR: IC REG LINEAR 3.3V 1.5A SOT223-6; Manufacturer: Texas Instruments; Regulator Output Type: Fixed; Polarity Type: Positive; Number of Outputs: 1; Load Regulation: 20mV; Line Regulation: 10mV; Input Voltage (Min): 1.9V; Input Voltage: 20V; Topology: LDO; Supplier Part Number 1: 296-24534-1-ND; Supplier 1: Digi-Key; Stock 1: 4026; Pricing 1: 1=2.88, 10=2.589, 25=2.4472, 100=2.0122, 250=1.8056, 500=1.7403, 1000=1.4466 (USD); Pin Count: 5 +Tab; Packaging: Tape and Reel; Package Type: SOT-223; Output Voltage: 3.3V; Output Current: 1.5A; Operating Temp Range: -40C to 125C; Operating Temperature Classification: Automotive; Mounting: Surface Mount; Manufacturer Part Number: TL1963A-33DCQR; Library Name: Arduino_Project_V1I1.SchLib; ComponentLink2URL: http://www.ti.com/lit/ds/symlink/tl1963a.pdf?src-supplier=Avnet+Europe; ComponentLink2Description: http://www.ti.com/lit/ds/symlink/tl1963a.pdf?src-supplier=Avnet+Europe; ComponentLink1URL: http://www.ti.com/lit/ds/symlink/tl1963a.pdf?src-supplier=Digi-Key; ComponentLink1Description: http://www.ti.com/lit/ds/symlink/tl1963a.pdf?src-supplier=Digi-Key; Component Kind: Standard
U3 = ATMEGA328P-PU: ATMEL         ATMEGA328P-PU            8 Bit Microcontroller, Low Power High Performance, ATmega, 20 MHz, 32 KB, 2 KB, 28 Pins, DIP; Manufacturer: Microchip / Atmel; Manuf. Code: ATM; Supply voltage (min.): 1.8 V; Supply voltage (max.): 5.5 V; Series (embedded microcontroller): AVR® ATmega; RAM size: 2 K x 8; Program memory type: FLASH; Program memory size: 32 KB (16 K x 16); Processor core: AVR; Peripherals: Brown-out Detect/Reset, POR, PWM, WDT; Oscillator type: Internal; I/O number: 23; EEPROM size: 1 K x 8; Data converter (embedded microcontroller): A/D 6x10b; Core size: 8-Bit; Connectivity: I²C, SPI, UART/USART; Clock speed: 20 MHz; Casing: PDIP 28; Type: ATMEGA328P-PU; Supplier Part Number 1: ATMEGA328P-PU-ND; Supplier 1: Digi-Key; Stock 1: 50; Pricing 1: 1=2.08, 25=1.91, 100=1.73 (USD); Operating temperature (min.): -40 °C; Max. operating temperature: +85 °C; Manufacturer Part Number: ATMEGA328P-PU; Library Name: Arduino_Project_V1I1.SchLib; ComponentLink6URL: http://www.produktinfo.conrad.com/datenblaetter/150000-174999/155197-da-01-en-MICROCONTR_ATMEGA328P_PU_8BIT_PDIP_28.pdf?src-supplier=Conrad; ComponentLink6Description: http://www.produktinfo.conrad.com/datenblaetter/150000-174999/155197-da-01-en-MICROCONTR_ATMEGA328P_PU_8BIT_PDIP_28.pdf?src-supplier=Conrad; ComponentLink5URL: http://datasheet.iiic.cc/atmel/ATMEGA328P-PU.pdf?src-supplier=IIIC; ComponentLink5Description: http://datasheet.iiic.cc/atmel/ATMEGA328P-PU.pdf?src-supplier=IIIC; ComponentLink4URL: http://www.microchip.com/mymicrochip/filehandler.aspx?ddocname=en589968&src-supplier=Jameco; ComponentLink4Description: http://www.microchip.com/mymicrochip/filehandler.aspx?ddocname=en589968&src-supplier=Jameco; ComponentLink3URL: http://www.microchip.com/mymicrochip/filehandler.aspx?ddocname=en589968&src-supplier=Verical; ComponentLink3Description: http://www.microchip.com/mymicrochip/filehandler.aspx?ddocname=en589968&src-supplier=Verical; ComponentLink2URL: http://www.rapidonline.com/pdf/73-4283.pdf?src-supplier=Rapid+Electronics; ComponentLink2Description: http://www.rapidonline.com/pdf/73-4283.pdf?src-supplier=Rapid+Electronics; ComponentLink1URL: http://www.farnell.com/datasheets/2047852.pdf?src-supplier=Element14; ComponentLink1Description: http://www.farnell.com/datasheets/2047852.pdf?src-supplier=Element14; Component Kind: Standard
U4-U7 = SN74AHC1G09DBVR: IC GATE AND 1CH 2-INP SOT23-5; Manufacturer: Texas Instruments; Voltage - Supply: 2 V ~ 5.5 V; Other Names: 296-29202-6; Number of Inputs: 2; Number of Circuits: 1; Max Propagation Delay @ V, Max CL: 7.5ns @ 5V, 50pF; Logic Type: AND Gate; Logic Level - Low: 0.5 V ~ 1.65 V; Logic Level - High: 1.5 V ~ 3.85 V; Dynamic Catalog: 74AHC; Current - Quiescent (Max): 1µA; Current - Output High, Low: -, 8mA; Supplier Part Number 1: 296-29202-6-ND; Supplier Device Package: SOT-23-5; Supplier 1: Digi-Key; Stock 1: 11961; Standard Package: 1; Series: 74AHC; Pricing 1: 1=0.33, 10=0.247, 25=0.2164, 100=0.14, 250=0.1159, 500=0.0927, 1000=0.0711 (USD); Packaging: Digi-Reel®; Package / Case: SC-74A, SOT-753; Operating Temperature: -55°C ~ 125°C; Mounting Type: Surface Mount; Manufacturer Part Number: SN74AHC1G09DBVR; Library Name: Arduino_Project_V1I1.SchLib; Features: Open Drain; Family: Logic - Gates and Inverters; ComponentLink1URL: http://www.ti.com/lit/ds/symlink/sn74ahc1g09.pdf?src-supplier=Digi-Key; ComponentLink1Description: http://www.ti.com/lit/ds/symlink/sn74ahc1g09.pdf?src-supplier=Digi-Key; Component Kind: Standard; Category: Integrated Circuits (ICs)
X1 = ABM7-8.000MHZ-D2Y-T: ABRACON - ABM7-8.000MHZ-D2Y-T - Crystal, Miniature, 8 MHz, SMD, 6mm x 3.5mm, 30 ppm, 18 pF, 20 ppm, ABM7 Series; Other Names: 535-9831-2; Operating Mode: Fundamental; Load Capacitance: 18pF; Frequency Tolerance: ±20ppm; Frequency Stability: ±30ppm; Frequency: 8MHz; Featured Product: ABM7 and ABM11 Crystals; ESR (Equivalent Series Resistance): 100 Ohm; Catalog Drawings: ABM7 Series Top; 3D Model: ABM7.pdf; Type: MHz Crystal; Supplier Part Number 1: 535-9831-1-ND; Supplier 1: Digi-Key; Stock 1: 95369; Standard Package: 1,000; Size / Dimension: 0.236" L x 0.138" W (6.00mm x 3.50mm); Series: ABM7; RoHS Information: RoHS2/REACH Compliance; Pricing 1: 1=1, 10=0.886, 50=0.8278, 100=0.7315, 500=0.693 (USD); Packaging: Tape & Reel (TR); Package / Case: 2-SMD; Operating Temperature: -40°C ~ 85°C; Online Catalog: ABM7 Series; Mounting Type: Surface Mount; Manufacturer Part Number: ABM7-8.000MHZ-D2Y-T; Manufacturer: Abracon; Library Name: Arduino_Project_V1I1.SchLib; Height: 0.055" (1.40mm); Family: Crystals; ComponentLink6URL: http://www.abracon.com/Support/PackageDrawing/Resonators/ABM7.PDF?src-supplier=Verical; ComponentLink6Description: http://www.abracon.com/Support/PackageDrawing/Resonators/ABM7.PDF?src-supplier=Verical; ComponentLink5URL: http://www.farnell.com/datasheets/2581424.pdf?src-supplier=Element14; ComponentLink5Description: http://www.farnell.com/datasheets/2581424.pdf?src-supplier=Element14; ComponentLink4URL: http://www.abracon.com/Resonators/abm7.pdf?src-supplier=Future+Electronics; ComponentLink4Description: http://www.abracon.com/Resonators/abm7.pdf?src-supplier=Future+Electronics; ComponentLink3URL: https://www.verical.com/server-webapp/html/getDatasheet?partID=722403&src-supplier=Verical; ComponentLink3Description: https://www.verical.com/server-webapp/html/getDatasheet?partID=722403&src-supplier=Verical; ComponentLink2URL: http://www.abracon.com/Resonators/abm7.pdf?src-supplier=Avnet; ComponentLink2Description: http://www.abracon.com/Resonators/abm7.pdf?src-supplier=Avnet; ComponentLink1URL: http://www.mouser.com/ds/2/3/abm7-19096.pdf?src-supplier=Mouser; ComponentLink1Description: http://www.mouser.com/ds/2/3/abm7-19096.pdf?src-supplier=Mouser; Component Kind: Standard; Category: Crystals and Oscillators
X2 = ABM7-10.000MHZ-D2Y-T: CRYSTAL 10.0000MHZ 18PF SMD; Manufacturer: Abracon; Other Names: 535-9840-2  ABM7-16.000MHZ-D-2-Y-T  ABM716000MHZD2YT; Operating Mode: Fundamental; Online Catalog: ABM7 Series; Frequency Tolerance: ±20ppm; Frequency Stability: ±30ppm; Frequency: 10MHz; Featured Product: ABM7 and ABM11 Crystals; ESR (Equivalent Series Resistance): 60 Ohms; Catalog Drawings: ABM7 Series Top    ABM7 Series Side    ABM7 Series Bottom; 3D Model: ABM7.pdf    ABM7.stp; Categories: Crystals, Oscillators, Resonators; Type: MHz Crystal; Supplier Part Number 1: 535-9833-1-ND; Supplier 1: Digi-Key; Stock 1: 10037; Standard Package: 1,000; Size / Dimension: 0.236 L x 0.138 W (6.00mm x 3.50mm); Series: ABM7; RoHS Information: RoHS2/REACH Compliance; Pricing 1: 1=1, 10=0.886, 50=0.8278, 100=0.7315, 500=0.693 (USD); Packaging: Digi-Reel®; Package / Case: 2-SMD, No Lead; Operating Temperature: -40°C ~ 85°C; Mounting Type: Surface Mount; Manufacturer Part Number: ABM7-10.000MHZ-D2Y-T; Load Capacitance: 18pF; Library Name: Arduino_Project_V1I1.SchLib; Height: 0.055" (1.40mm); Family: Crystals; ComponentLink6URL: http://www.abracon.com/Support/PackageDrawing/Resonators/ABM7.PDF?src-supplier=Verical; ComponentLink6Description: http://www.abracon.com/Support/PackageDrawing/Resonators/ABM7.PDF?src-supplier=Verical; ComponentLink5URL: https://abracon.com/Resonators/abm7.pdf?src-supplier=Digi-Key; ComponentLink5Description: https://abracon.com/Resonators/abm7.pdf?src-supplier=Digi-Key; ComponentLink4URL: http://www.abracon.com/Resonators/abm7.pdf?src-supplier=Future+Electronics; ComponentLink4Description: http://www.abracon.com/Resonators/abm7.pdf?src-supplier=Future+Electronics; ComponentLink3URL: http://www.abracon.com/Resonators/abm7.pdf?src-supplier=Farnell; ComponentLink3Description: http://www.abracon.com/Resonators/abm7.pdf?src-supplier=Farnell; ComponentLink2URL: http://www.farnell.com/datasheets/947971.pdf?src-supplier=Element14; ComponentLink2Description: http://www.farnell.com/datasheets/947971.pdf?src-supplier=Element14; ComponentLink1URL: http://www.abracon.com/Resonators/abm7.pdf?src-supplier=Future+Electronics; ComponentLink1Description: http://www.abracon.com/Resonators/abm7.pdf?src-supplier=Future+Electronics; Component Kind: Standard; Category: Crystals and Oscillators; Height - Seated (Max): 0.055 (1.40mm); Part Status: Active; Ratings: -
X2 = ABM7-10.000MHZ-D2Y-T: CRYSTAL 10.0000MHZ 18PF SMD; Manufacturer: Abracon; Operating Mode: Fundamental; Frequency Tolerance: ±20ppm; Frequency Stability: ±30ppm; Frequency: 10MHz; ESR (Equivalent Series Resistance): 60 Ohms; Categories: Crystals, Oscillators, Resonators; Type: MHz Crystal; Supplier Part Number 1: 535-9833-1-ND; Supplier 1: Digi-Key; Stock 1: 10037; Size / Dimension: 0.236 L x 0.138 W (6.00mm x 3.50mm); Series: ABM7; Ratings: -; Pricing 1: 1=1, 10=0.886, 50=0.8278, 100=0.7315, 500=0.693 (USD); Part Status: Active; Packaging: Digi-Reel®; Package / Case: 2-SMD, No Lead; Operating Temperature: -40°C ~ 85°C; Mounting Type: Surface Mount; Manufacturer Part Number: ABM7-10.000MHZ-D2Y-T; Load Capacitance: 18pF; Library Name: Arduino_Project_V1I1.SchLib; Height - Seated (Max): 0.055 (1.40mm); ComponentLink1URL: http://www.abracon.com/Resonators/abm7.pdf?src-supplier=Future+Electronics; ComponentLink1Description: http://www.abracon.com/Resonators/abm7.pdf?src-supplier=Future+Electronics; Component Kind: Standard
Z1 Z2 = CG0603MLC-05E: VARISTOR 0603; Manufacturer: Bourns; Voltage Rating DC: 5 V; Current Rating: 50 nA; Clamping Voltage: 20 V; Channels: 1 Channel; Case Width: 0.8 mm; Capacitance: 0.5 pF; Width: 0.8 mm; Voltage: 5 V; Tradename: ChipGuard; Termination Style: SMD/SMT; Supplier Part Number 1: CG0603MLC-05ECT-ND; Supplier 1: Digi-Key; Stock 1: 91997; Series: MLC; RoHS: Details; Product Category: Varistors; Product: MLV; Pricing 1: 1=0.51, 10=0.359, 25=0.2944, 100=0.2357, 250=0.1714, 500=0.1393, 1000=0.1071, 2500=0.0964 (USD); Packaging: Reel; Package / Case: 0603 (1608 metric); Operating Voltage: 5 V; Operating Temperature Range: - 40 C to + 85 C; Mounting: SMD/SMT; Manufacturer Part Number: CG0603MLC-05E; Library Name: Arduino_Project_V1I1.SchLib; Factory Pack Quantity: 5000; Dimensions: 0.8 mm W x 1.6 mm L x 0.8 mm H; ComponentLink5URL: http://www.bourns.com/docs/Product-Datasheets/MLC.pdf?src-supplier=Avnet; ComponentLink5Description: http://www.bourns.com/docs/Product-Datasheets/MLC.pdf?src-supplier=Avnet; ComponentLink4URL: http://www.bourns.com/docs/Product-Datasheets/MLC.pdf?src-supplier=Avnet+Europe; ComponentLink4Description: http://www.bourns.com/docs/Product-Datasheets/MLC.pdf?src-supplier=Avnet+Europe; ComponentLink3URL: http://www.jameco.com/Jameco/Products/ProdDS/1163231.pdf?src-supplier=Jameco; ComponentLink3Description: http://www.jameco.com/Jameco/Products/ProdDS/1163231.pdf?src-supplier=Jameco; ComponentLink2URL: http://www.farnell.com/datasheets/2360367.pdf?src-supplier=Element14; ComponentLink2Description: http://www.farnell.com/datasheets/2360367.pdf?src-supplier=Element14; ComponentLink1URL: https://www.bourns.com/docs/Product-Datasheets/MLC.pdf?src-supplier=Digi-Key; ComponentLink1Description: https://www.bourns.com/docs/Product-Datasheets/MLC.pdf?src-supplier=Digi-Key; Component Kind: Standard; Case Code - mm: 1608; Case Code - in: 0603; Brand: Bourns
